# Altium schematic sheet IR_Receiver_Part.SchDoc
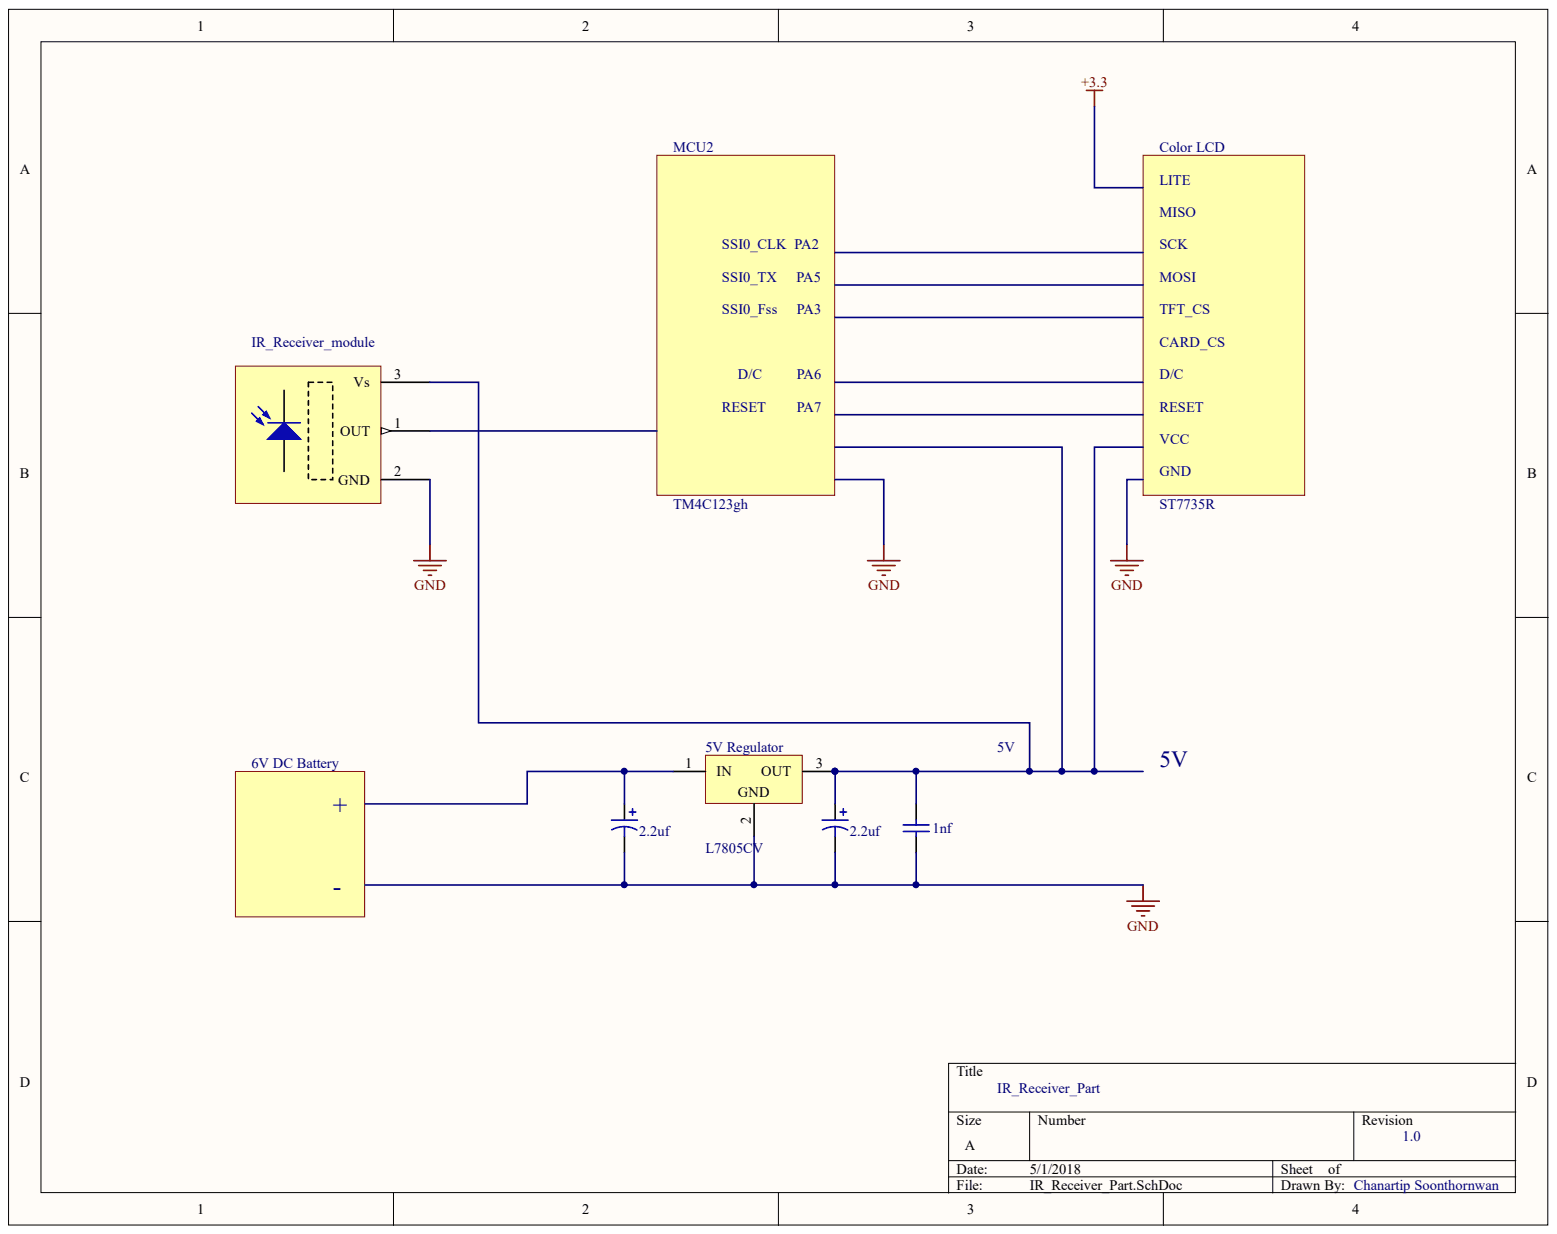
[diagram p0: IR_Receiver_Part.SchDoc]

## Parts
1nf  CAP-2  [CAPC3216X178X50LL20T25]  (value not drawn)
2.2uf  SYM-1635dc727a7cc9f2-1  [PCB-3fis2k4xlpvnsk81kkhj-1]  (value not drawn)
2.2uf  SYM-1635dc727a7cc9f2-1  [PCB-3fis2k4xlpvnsk81kkhj-1]  (value not drawn)
5V_Regulator  L7805CV  [TO220]
IR_Receiver_module  SYM-55309c6adf858af7-1  [PCB-asgm0wqc3q73jpd3bzgc-1]  (value not drawn)

## Nets
GND: 1nf.1 2.2uf.2 5V_Regulator.2 IR_Receiver_module.2
Net1nf_2: 1nf.2 2.2uf.1 5V_Regulator.3 IR_Receiver_module.3(Vs)
Net2.2uf_1: 2.2uf.1 5V_Regulator.1
+3.3:
N00003:
N00004:
N00005:
N00006:
N00007:

Not connected: IR_Receiver_module 1

## Library data of the parts
1nf = 12061C105K4T2A: Multilayer Ceramic Capacitors MLCC - SMD/SMT 1uF 100 Volts X7R 10%; Capacitance: 1e-06 F; Lead-Free Status: Lead Free; Voltage Rating (DC): 100.0 V; Capacitance Tolerance: ±10%; Case/Package: 1206; Component Kind: Standard; Dielectric Characteristic: X7R; Library Name: Octopart library; OctopartID: eb6b2332115d34ac; Octopart Url: https://octopart.com/12061c105k4t2a-avx+interconnect+%2F+elco-22273872; RoHS: Compliant
2.2uf 2.2uf = ECA-1CM102: Cap Aluminum 1000uF 16V 20% (10 X 16mm) Radial Aluminum Cylindrical Can 5mm 680mA 2000 hr 85°C Bulk; Manufacturer Part Number: ECA1CM102; Capacitance: 1.00 mF; Dielectric Material: Aluminum Electrolytic; Lead-Free Status: Lead Free; Voltage Rating (DC): 16.0 V; Component Kind: Standard; Library Name: Octopart library; Lifecycle Status: Not Listed by Manufacturer; Mounting Style: Through Hole; Number of Pins: 2; OctopartID: 1635dc727a7cc9f2; Octopart URL: http://octopart.com/eca1cm102-panasonic-55396655; Packaging: Bulk; RoHS: Compliant; Size-Diameter: 10.0 mm; Size-Length: 16.0 mm
5V_Regulator = L7805CV: Standard Regulator Pos 5V 1.5A 3-Pin(3+Tab) TO-220 Standard Regulator Pos 5V 1.5A 3-Pin(3+Tab) TO-220; Component Kind: Standard; Library Name: Octopart library
IR_Receiver_module = TSOP2438: 38 kHz 45 m 2.5-5.5 V Through Hole IR Receiver Module for Remote Control System; Lead-Free Status: Lead Free; Lead Length: 23.6 mm; Supply Current: 5.00 mA; Supply Voltage (DC): 4.50 V (min); Component Kind: Standard; Library Name: Octopart library; Manufacturer Part Number: TSOP2438; OctopartID: 55309c6adf858af7; Octopart URL: http://octopart.com/tsop2438-vishay-5287891; REACH SVHC Compliance: No SVHC; RoHS: Compliant
